# Altium schematic sheet Sheet1.SchDoc(Sheet1)
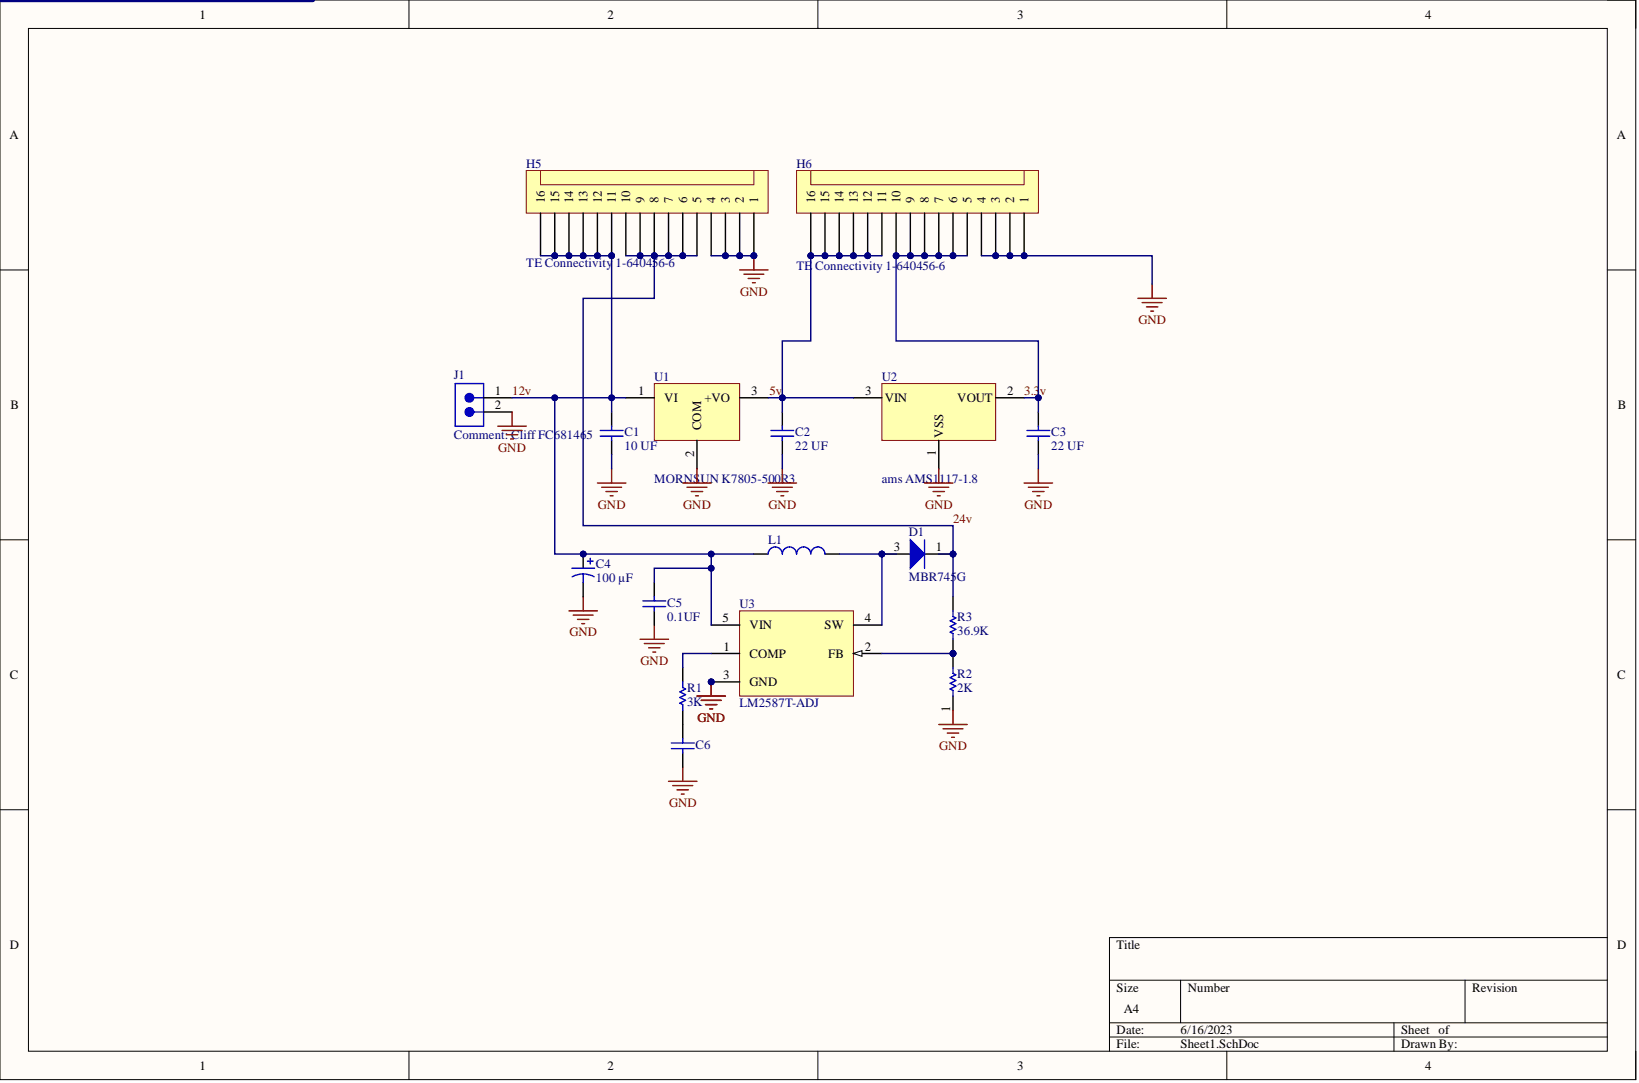
[diagram p0: Sheet1.SchDoc]

## Parts
C1  10 UF
C2  22 UF
C3  22 UF
C4  100 µF
C5  0.1UF
C6  AVX Interconnect / Elco 12063C684KAT2A  (value not drawn)
D1  MBR745G
H5  TE Connectivity 1-640456-6  Manufacturer Part Number: 1-640456-6  [PCB-345877-1]
H6  TE Connectivity 1-640456-6  Manufacturer Part Number: 1-640456-6  [PCB-345877-1]
J1  Cliff FC681465
L1  Coilcraft 1206CS-330XGLB  (value not drawn)
R1  3K
R2  2K
R3  36.9K
U1  MORNSUN K7805-500R3  Manufacturer Part Number: K7805-500R3  [PCB-mmr4y0vpp49o6va857n6-1]
U2  ams AMS1117-1.8  [PCB-ooy7imu5yymd5fv31n85-1]
U3  LM2587T-ADJ

## Nets
GND: C1.2 C2.1 C3.2 C4.2 C5.1 C6.1 H5.1 H5.2 H5.3 H5.4 H6.1 H6.2 H6.3 H6.4 J1.2 R2.1 U1.2 U2.1 U3.3(GND)
3.3v: C3.1 H6.5 H6.6 H6.7 H6.8 H6.9 H6.10 U2.2
5v: C2.2 H6.11 H6.12 H6.13 H6.14 H6.15 H6.16 U1.3 U2.3
12v: C1.1 C4.1 C5.2 H5.11 H5.12 H5.13 H5.14 H5.15 H5.16 J1.1 L1.1 U1.1 U3.5(VIN)
24v: D1.1 H5.5 H5.6 H5.7 H5.8 H5.9 H5.10 R3.2
NetC6_2: C6.2 R1.1
NetD1_3: D1.3 L1.2 U3.4(SW)
NetR1_2: R1.2 U3.1(COMP)
NetR2_2: R2.2 R3.1 U3.2(FB)

## Library data of the parts
C1 = CMP-127038-2: CAP CER 10UF 25V 10% X5R 1206; Manufacturer Part Number: 12063D106KAT2A; Capacitance: 1e-05 F; Lead-Free Status: Lead Free; Voltage Rating (DC): 25.0 V; Capacitance Tolerance: ±10%; Case/Package: 1206; Component Kind: Standard; Dielectric Characteristic: X5R; Library Name: My Personal Space; Mounting Style: Surface Mount; Number of Pins: 2; Octopart Url: https://octopart.com/12063d106kat2a-avx+interconnect+%2F+elco-7184313; OctopartID: c5990cfe7f461a5e; REACH SVHC Compliance: No SVHC; RoHS: Compliant
C2 C3 = CMP-3991792-2: AVX   TPSS226K006R0900   CAP, TANT, 22UF, 6.3V, CASE S; Capacitance: 2.2e-05 F; Capacitance Tolerance: ±10%; Dielectric Material: Tantalum; Equivalent Series Resistance (ESR): 0.9 Ω; Lead-Free Status: Lead Free; Case/Package: 1206; Component Kind: Standard; Library Name: My Personal Space; Manufacturer Part Number: TPSS226K006R0900; Number of Pins: 2; Octopart Url: https://octopart.com/tpss226k006r0900-avx+interconnect+%2F+elco-8398222; OctopartID: 41cb884141239e0c; Packaging: Cut Tape (CT); REACH SVHC Compliance: No SVHC; RoHS: Compliant; Voltage Rating (DC): 6.3 V
C4 = CMP-e6412bdb57d93986-1: Tantalum Polymer Capacitor, 100 uF, 6.3 V, ± 20%, A, 45 mohm, 1206 [3216-18 Metric]; Manufacturer Part Number: T520A107M006ATE045; Equivalent Series Resistance (ESR): 45.0 mΩ; Voltage Rating (DC): 6.30 V; Capacitance: 100 µF; Capacitance Tolerance: ±20%; Component Kind: Standard; Library Name: My Personal Space; Mounting Style: Surface Mount; Octopart Url: https://octopart.com/t520a107m006ate045-kemet-76584190; OctopartID: e6412bdb57d93986; Operating Temperature: 105 °C (max); Packaging: Cut Tape (CT); RoHS: Compliant
C5 = CMP-175552-2: CAP CER 0.1UF 100V 20% X7R 1206; Manufacturer Part Number: 12061C104M4T2A; Capacitance: 1e-07 F; Case/Package (SI): 3216; Lead-Free Status: Lead Free; Voltage Rating (DC): 100.0 V; Capacitance Tolerance: ±20%; Case/Package: 1206; Component Kind: Standard; Dielectric Characteristic: X7R; Library Name: My Personal Space; Mounting Style: Surface Mount; Number of Pins: 2; Octopart Url: https://octopart.com/12061c104m4t2a-avx+interconnect+%2F+elco-2483312; OctopartID: bbf972d67aef643e; Packaging: Tape; RoHS: Compliant
C6 = CMP-126982-2: CAP CER 0.68UF 25V 10% X7R 1206; Capacitance: 6.8e-07 F; Case/Package (SI): 3216; Lead-Free Status: Lead Free; Voltage Rating (DC): 25.0 V; Capacitance Tolerance: ±10%; Case/Package: 1206; Component Kind: Standard; Dielectric Characteristic: X7R; Library Name: My Personal Space; Manufacturer Part Number: 12063C684KAT2A; Mounting Style: Surface Mount; Number of Pins: 2; Octopart Url: https://octopart.com/12063c684kat2a-avx+interconnect+%2F+elco-195084; OctopartID: 4d88848092e2924f; REACH SVHC Compliance: No SVHC; RoHS: Compliant; Size-Height: 0.00178 m; Size-Length: 0.0032 m; Size-Width: 0.0016 m
D1 = CMP-816931-2: SWITCHMODE(TM) Power Rectifier, 2-Pin TO-220, Pb-Free, Tube; Current Rating: 7.5 A; Forward Voltage: 0.57 V; Lead-Free Status: Lead Free; Polarity: Standard; Voltage Rating (DC): 45.0 V; Case/Package: TO-220-2; Component Kind: Standard; Library Name: My Personal Space; Lifecycle Status: Active; Manufacturer Part Number: MBR745G; Mounting Style: Through Hole; Number of Pins: 2; Octopart Url: https://octopart.com/mbr745g-on+semiconductor-144398; OctopartID: c78a0e82d6e2184d; Packaging: Bulk; REACH SVHC Compliance: No SVHC; RoHS: Compliant
H5 H6 = CMP-5cb5977adcc98372-4: Header, Friction Lock; MTA-100; 16; 250 VAC; Polyester; Tin; Copper Alloy; Tin; ELV Compliant: Compliant; Gender: Male; Lead-Free Status: Lead Free; Mounting Angle: 180 °; Number of Contacts: 16; Pin Pitch: 2.54 mm; Shrouded: No; Voltage Rating (AC): 250 V; Color: White; Component Kind: Standard; Contact Material: Copper Alloy; Contact Plating: Tin; Flammability Rating: UL 94 V-0; Housing Color: White; Housing Material: Polyester; Library Name: My Personal Space; Lifecycle Status: Active; Mounting Style: Through Hole, Vertical; Number of Positions: 16; Number of Rows: 1; Octopart URL: https://octopart.com/1-640456-6-te+connectivity-46507290; OctopartID: 5cb5977adcc98372; Operating Temperature: 105 °C (max); Orientation: Vertical; Packaging: Bag; REACH SVHC Compliance: No SVHC; RoHS: Compliant; Size-Height: 7.87 mm; Size-Length: 40.6 mm; Size-Width: 5.71 mm; Voltage Rating (DC): 250 V
J1 = CMP-7825b33a9823a7e2-3: DC-Power Socket 12V 5A 2, 1/2, 5mm Solder; Component Kind: Standard; Library Name: My Personal Space
L1 = CMP-2f10e67d0172f0d7-1: RF inductor, ceramic core, 2% tol, SMT, RoHS; Current Rating: 1.00 A; Inductance: 33.0 nH; Lead-Free Status: Contains Lead; Q-Factor: 55.0; Self Resonant Frequency: 1.80 GHz; Case/Package: 3216; Component Kind: Standard; Library Name: My Personal Space; Lifecycle Status: Active; Manufacturer Part Number: 1206CS-330XGLB; Mounting Style: Surface Mount; Octopart Url: https://octopart.com/1206cs-330xglb-coilcraft-6753028; OctopartID: 2f10e67d0172f0d7; Packaging: Cut Tape (CT); REACH SVHC Compliance: No SVHC; RoHS: Compliant
R1-R3 = CMP-3678153-2: Thick Film Resistors - SMD 1206 2.67Kohms 1% Tolerance; Manufacturer Part Number: ERJ-8ENF2671V; Case/Package (SI): 3216; Lead-Free Status: Lead Free; Power Rating: 0.25 W; Resistance: 2670.0 Ω; Voltage Rating (DC): 200.0 V; Case/Package: 1206; Component Kind: Standard; Library Name: My Personal Space; Lifecycle Status: Not Listed by Manufacturer; Mounting Style: Surface Mount; Octopart Url: https://octopart.com/erj-8enf2671v-panasonic-55423450; OctopartID: a5c76f80317b7a00; REACH SVHC Compliance: No SVHC; Resistance Tolerance: ±1%; RoHS: Compliant; Size-Height: 0.0006 m; Size-Length: 0.002 m; Size-Width: 0.0016 m
U1 = CMP-d9dc14ef0d81061b-2: DC/DC Converter Non-Isolated 500mA 24Vin +-5Vout; Input Voltage Range: 6.5-36V (+5Vout) or 7-31V (-5Vout); Max. Capacitive Load: 680µF (+5Vout) or 330µF (-5Vout); Output Current: 500mA or -300mA; Output Voltage: +5V or -5V, depending on Pins 2/3 connection; Component Kind: Standard; Library Name: My Personal Space; Octopart URL: https://octopart.com/k7805-500r3-mornsun-70906171; OctopartID: d9dc14ef0d81061b
U2 = CMP-04bccc1ca4d5db7b-3: AMS1117-1.8; Component Kind: Standard; Library Name: My Personal Space; Manufacturer Part Number: AMS1117-1.8; Octopart URL: http://octopart.com/ams1117-1.8-ams-27952399; OctopartID: 04bccc1ca4d5db7b
U3 = CMP-0069-01970-3: SIMPLE SWITCHER® 5A Flyback Regulator, 5-pin TO-220; Frequency: 100000.0 Hz; Lead-Free Status: Contains Lead; Number of Outputs: 1; Output Current: 5.0 A; Output Voltage: 60.0 V; Quiescent Current: 0.011 A; Case/Package: TO-220; Component Kind: Standard; Library Name: My Personal Space; Lifecycle Status: Active; Manufacturer Part Number: LM2587T-ADJ; Mounting Style: Through Hole; Number of Pins: 5; Number of Regulated Outputs: 1; Octopart Url: https://octopart.com/lm2587t-adj-texas+instruments-24844494; OctopartID: 83bc4bcdbe145c7b; Packaging: Rail; REACH SVHC Compliance: No SVHC; RoHS: Non-Compliant
